# Altium schematic sheet Processor.SchDoc
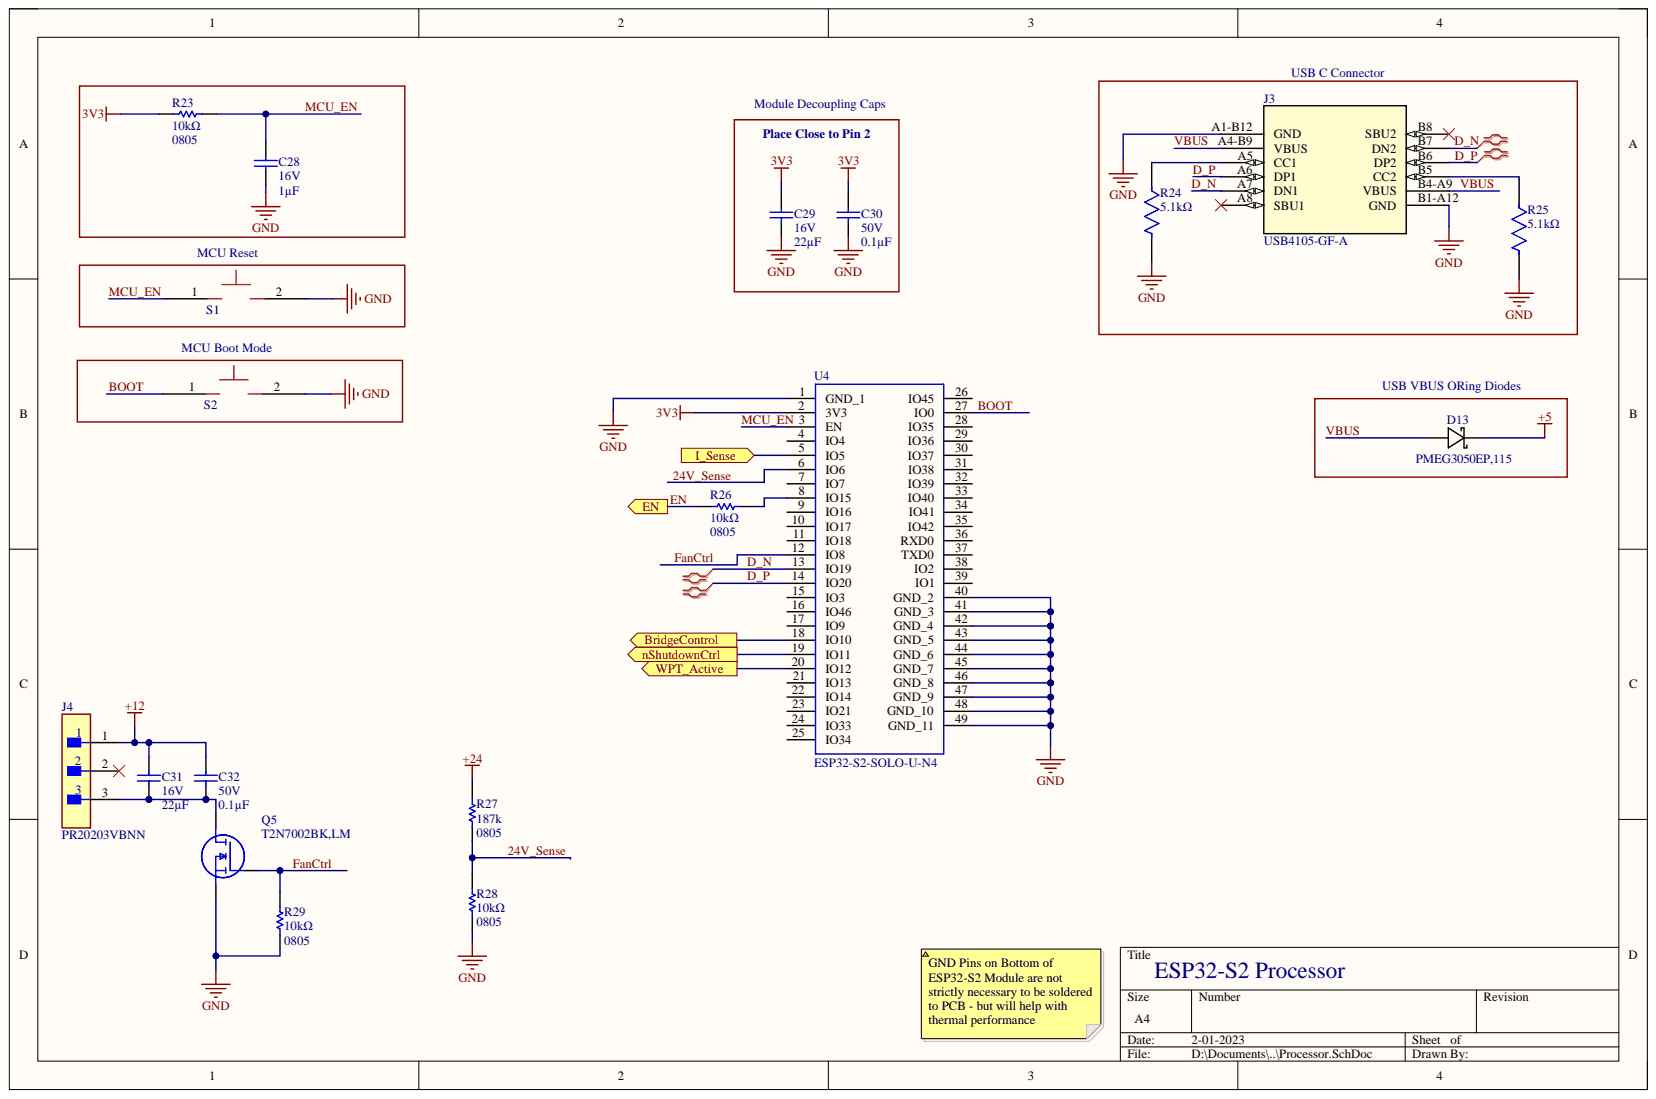
[diagram p0: Processor.SchDoc]

## Parts
C28  Capacitance: 1µF  Voltage - Rated: 16V  [CAP0805]  (hidden comment: GRM21BR71C105KA01L)
C29  Capacitance: 22µF  Voltage - Rated: 16V  [0805]  (hidden comment: CL21A226MOCLRNC)
C30  Capacitance: 0.1µF  Voltage - Rated: 50V  [CAP0805]  (hidden comment: C0805C104K5RACTU)
C31  Capacitance: 22µF  Voltage - Rated: 16V  [0805]  (hidden comment: CL21A226MOCLRNC)
C32  Capacitance: 0.1µF  Voltage - Rated: 50V  [CAP0805]  (hidden comment: C0805C104K5RACTU)
D13  MFG_PN: PMEG3050EP,115  [SOD-128]  (hidden comment: D_PMEG3050EP,115)
J3  USB4105-GF-A  [USB4105_GCT]
J4  PR20203VBNN  [FP-PR20203VBNN-MFG]
Q5  T2N7002BK,LM  [TBAT54CLM]
R23  Package / Case: 0805  Resistance: 10kΩ  [RES0805]  (hidden comment: RC0805FR-0710KL)
R24  Resistance: 5.1kΩ  [FP-CRCW0805-e3-MFG]  (hidden comment: CRCW08055K10FKEA)
R25  Resistance: 5.1kΩ  [FP-CRCW0805-e3-MFG]  (hidden comment: CRCW08055K10FKEA)
R26  Package / Case: 0805  Resistance: 10kΩ  [RES0805]  (hidden comment: RC0805FR-0710KL)
R27  Package: 0805  Resistance: 187k  [RESC2013X70X40NL20T20]  (hidden comment: ERJ-6ENF1873V)
R28  Package / Case: 0805  Resistance: 10kΩ  [RES0805]  (hidden comment: RC0805FR-0710KL)
R29  Package / Case: 0805  Resistance: 10kΩ  [RES0805]  (hidden comment: RC0805FR-0710KL)
S1  RESET  [Tactile_Button_3X6X2]  (value not drawn)
S2  BOOT  [Tactile_Button_3X6X2]
U4  ESP32-S2-SOLO-U-N4  [ESP32S2SOLOUN4]

## Ports
BridgeControl, EN, I_Sense, nShutdownCtrl, WPT_Active

## Nets
3V3: C29.2 C30.2 R23.1 U4.2(3V3)
+5: D13.2
+12: C31.2 C32.2 J4.1
GND: C28.1 C29.1 C30.1 J3.A1-B12(GND) J3.B1-A12(GND) Q5.2 R24.2 R25.2 R28.1 R29.1 S1.2 S2.2 U4.1(GND_1) U4.40(GND_2) U4.41(GND_3) U4.42(GND_4) U4.43(GND_5) U4.44(GND_6) U4.45(GND_7) U4.46(GND_8) U4.47(GND_9) U4.48(GND_10) U4.49(GND_11)
24V_Sense: R27.1 R27.2 R28.2 U4.6(IO6)
BOOT: S2.1 U4.27(IO0)
BridgeControl: U4.18(IO10) ; port BridgeControl
D_N: J3.A7(DN1) J3.B7(DN2) U4.13(IO19)
D_P: J3.A6(DP1) J3.B6(DP2) U4.14(IO20)
EN: R26.1 ; port EN
FanCtrl: Q5.1 R29.2 U4.12(IO8)
I_Sense: U4.5(IO5) ; port I_Sense
MCU_EN: C28.2 R23.2 S1.1 U4.3(EN)
nShutdownCtrl: U4.19(IO11) ; port nShutdownCtrl
VBUS: D13.1 J3.A4-B9(VBUS) J3.B4-A9(VBUS)
WPT_Active: U4.20(IO12) ; port WPT_Active
NetC31_1: C31.1 C32.1 J4.3 Q5.3
NetJ3_A5: J3.A5(CC1) R24.1
NetJ3_B5: J3.B5(CC2) R25.1
NetR26_2: R26.2 U4.8(IO15)

Not connected: J3 A8(SBU1) B8(SBU2); J4 2; U4 4(IO4) 7(IO7) 9(IO16) 10(IO17) 11(IO18) 15(IO3) 16(IO46) 17(IO9) 21(IO13) 22(IO14) 23(IO21) 24(IO33) 25(IO34) 26(IO45) 28(IO35) 29(IO36) 30(IO37) 31(IO38) 32(IO39) 33(IO40) 34(IO41) 35(IO42) 36(RXD0) 37(TXD0) 38(IO2) 39(IO1)

## Library data of the parts
C28 = GRM21BR71C105KA01L: CAP CER 1UF 16V X7R 0805; Manufacturer: Murata Electronics North America; Tolerance: ±10%; Component Kind: Standard; Library Name: Capacitors.IntLib; Operating Temperature: -55°C ~ 125°C; Package / Case: 0805; Supplier 1: Digi-Key; Supplier Part Number 1: 490-1691-1-ND; Temperature Coefficient: X7R
C29 C31 = CL21A226MOCLRNC: CAP CER 22UF 16V X5R 0805; Tolerance: ±20%; Component Kind: Standard; Library Name: Capacitors.IntLib; Supplier 1: Digi-Key; Supplier Part Number 1: 1276-6780-1-ND; Temperature Coefficient: X5R
C30 C32 = C0805C104K5RACTU: CAP CER 0.1UF 50V X7R 0805; Tolerance: ±10%; Component Kind: Standard; Library Name: Capacitors.IntLib; Package / Case: 0805; Supplier 1: Digi-Key; Supplier Part Number 1: 399-1170-1-ND; Temperature Coefficient: X7R
D13 = D_PMEG3050EP,115: DIODE SCHOTTKY 360mV 30V 5A; MFG: Nexperia; Component Kind: Standard; Library Name: Diodes.IntLib; Supplier: Digikey; Supplier_PN: 1727-5317-1-ND
J3 = USB4105-GF-A: No Description Available; Mfr_Name: Global Connector Technology; Component Kind: Standard; Digi-Key_Part_Number_1: 2073-USB4105-GF-ACT-ND; Digi-Key_Part_Number_2: 2073-USB4105-GF-ADKR-ND; Digi-Key_Part_Number_3: 2073-USB4105-GF-ATR-ND; Library Name: Connectors and Headers.IntLib; Manufacturer_Part_Number: USB4105-GF-A; RefDes: RefDes; Type: DEV; Copyright: Copyright (C) 2022 Ultra Librarian. All rights reserved.
J4 = CMP-77940-000002-1: CONN HEADER VERT 3POS 2.54MM; Manufacturer: Metz Connect; Conn Gender: Male; Conn Orientation: Straight; Current Rating: 3A; Number Of Contacts: 3; Automotive: No; Category: Conn; Component Kind: Standard; ComponentLink2Description: Datasheet; ComponentLink2URL: https://datasheet.octopart.com/PR20203VBNN-Metz-Connect-datasheet-101610322.pdf; Contact Material: Gold,Nickel; Device Class L1: Connectors; Device Class L2: Headers and Wire Housings; Device Class L3: unset; Digikey Description: CONN HEADER VERT 3POS 2.54MM; Height: 8.6mm; Lead Free: Yes; Library Name: Altium Content Vault; Manufacturer Part Number: PR20203VBNN; Number Of Rows: 1; Octopart: https://octopart.com/pr20203vbnn-metz+connect-87667116; Package: PR20203VBNN; Pitch: 2.54mm; RoHS: TRUE; Temperature: -40°C to +105°C; Temperature Range High: +105°C; Temperature Range Low: -40°C
Q5 = T2N7002BK,LM: MOSFET (N-Channel); Manufacturer_Name: Toshiba; Arrow Part Number: T2N7002BK,LM; Arrow Price/Stock: https://www.arrow.com/en/products/t2n7002bklm/toshiba?region=nac; Component Kind: Standard; Datasheet Link: https://toshiba.semicon-storage.com/info/docget.jsp?did=30376&prodName=T2N7002BK; Height: 1.1mm; Manufacturer_Part_Number: T2N7002BK,LM; Mouser Part Number: 757-T2N7002BKLM; Mouser Price/Stock: https://www.mouser.co.uk/ProductDetail/Toshiba/T2N7002BKLM?qs=lX6dEUlT%252BgfTnU11z%2FTylg%3D%3D
R23 R26 R28 R29 = RC0805FR-0710KL: RES SMD 10K OHM 1% 1/8W 0805; Manufacturer: Yageo; Power (Watts): 0.125W, 1/8W; Temperature Coefficient: ±100ppm/°C; Component Kind: Standard; Composition: Thick Film; Features: Moisture Resistant; Library Name: Resistors.IntLib; Operating Temperature: -55°C ~ 155°C; Supplier 1: Digi-Key; Supplier Part Number 1: 311-10.0KCRCT-ND; Tolerance: ±1%
R24 R25 = CMP-2001-04024-2: RES Thick Film, 5.1kΩ, 1%, 0.125W, 100ppm/°C, 0805; Max Power Dissipation: 125mW; Voltage Rating: 150V; Case Code (Imperial): 0805; Case Code (Metric): 2012; Case/Package: 0805; Component Kind: Standard; Composition: Thick Film; Contact Plating: Tin; Depth: 1.25mm; Features: Automotive AEC-Q200; Height: 0.45mm; Lead Free: Lead Free; Length: 2mm; Library Name: Altium Content Vault; Max Operating Temperature: 155°C; Military Standard: Not; Min Operating Temperature: -55°C; Mount: Surface Mount; Number of Terminations: 2; Package Quantity: 10; Packaging: Tape and Reel; Power Rating: 125mW; Radiation Hardening: No; Temperature Coefficient: 100ppm/°C; Termination: SMD/SMT; Tolerance: 1%; Voltage Rating (DC): 150V; Weight: 0.000194oz; Width: 0.049inch
R27 = CMP-1013-00765-2: Manufacturer: Panasonic; Component Kind: Standard; Library Name: Altium Content Vault; Part Number: ERJ-6ENF1873V
S1 S2 = Tactile Button_3X6X2: Component Kind: Standard; Description: Tactile Button_3X6X2; Library Name: Mechanical_Devices.IntLib
U4 = ESP32-S2-SOLO-U-N4: WiFi Modules - 802.11 SMD module, ESP32-S2, 4 MB SPI flash, IPEX antenna; Manufacturer_Name: Espressif Systems; Geometry.Height: 3.35mm; Component Kind: Standard; Datasheet Link: https://www.espressif.com/sites/default/files/documentation/esp32-s2-solo_esp32-s2-solo-u_datasheet_en.pdf; Library Name: Microcontrollers.IntLib; Manufacturer_Part_Number: ESP32-S2-SOLO-U-N4; Mouser Part Number: 356-ESP32-S2-SOLOUN4; Mouser Price/Stock: https://www.mouser.co.uk/ProductDetail/Espressif-Systems/ESP32-S2-SOLO-U-N4?qs=pBJMDPsKWf24meOzbFo%252B2g%3D%3D
